# Altium schematic sheet RF.SchDoc(RF)
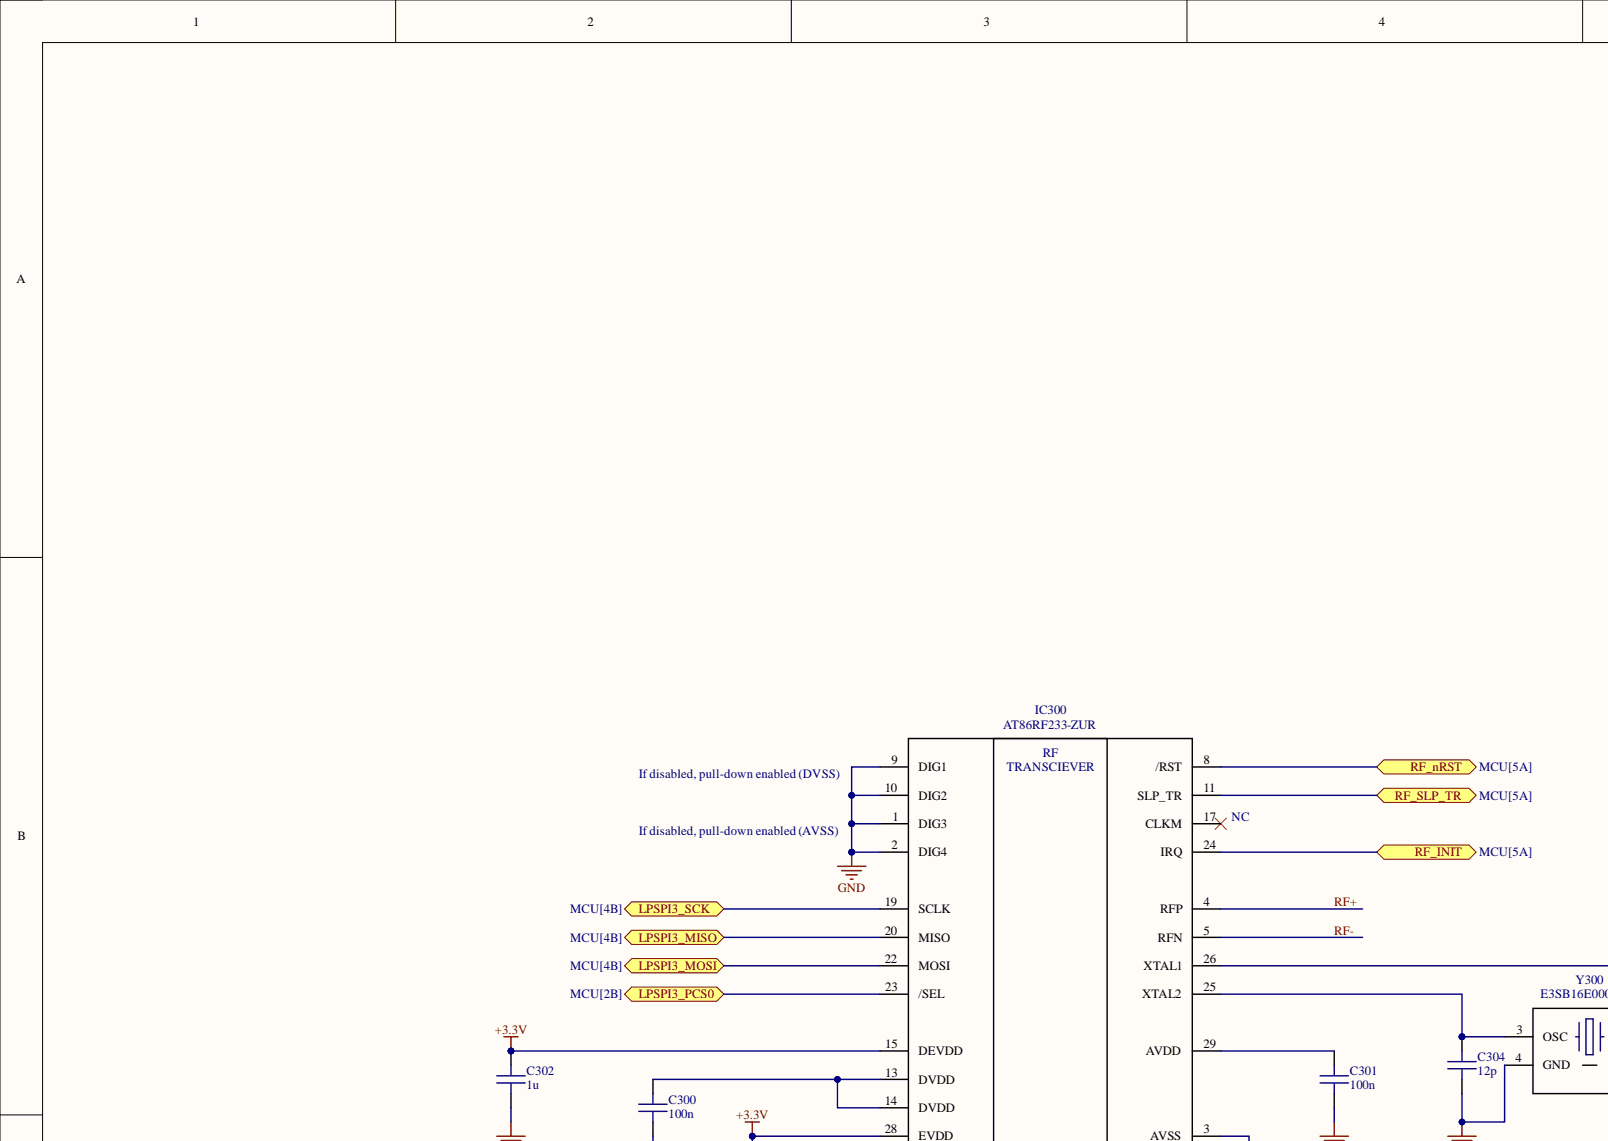
[diagram p0: RF.SchDoc, top left]
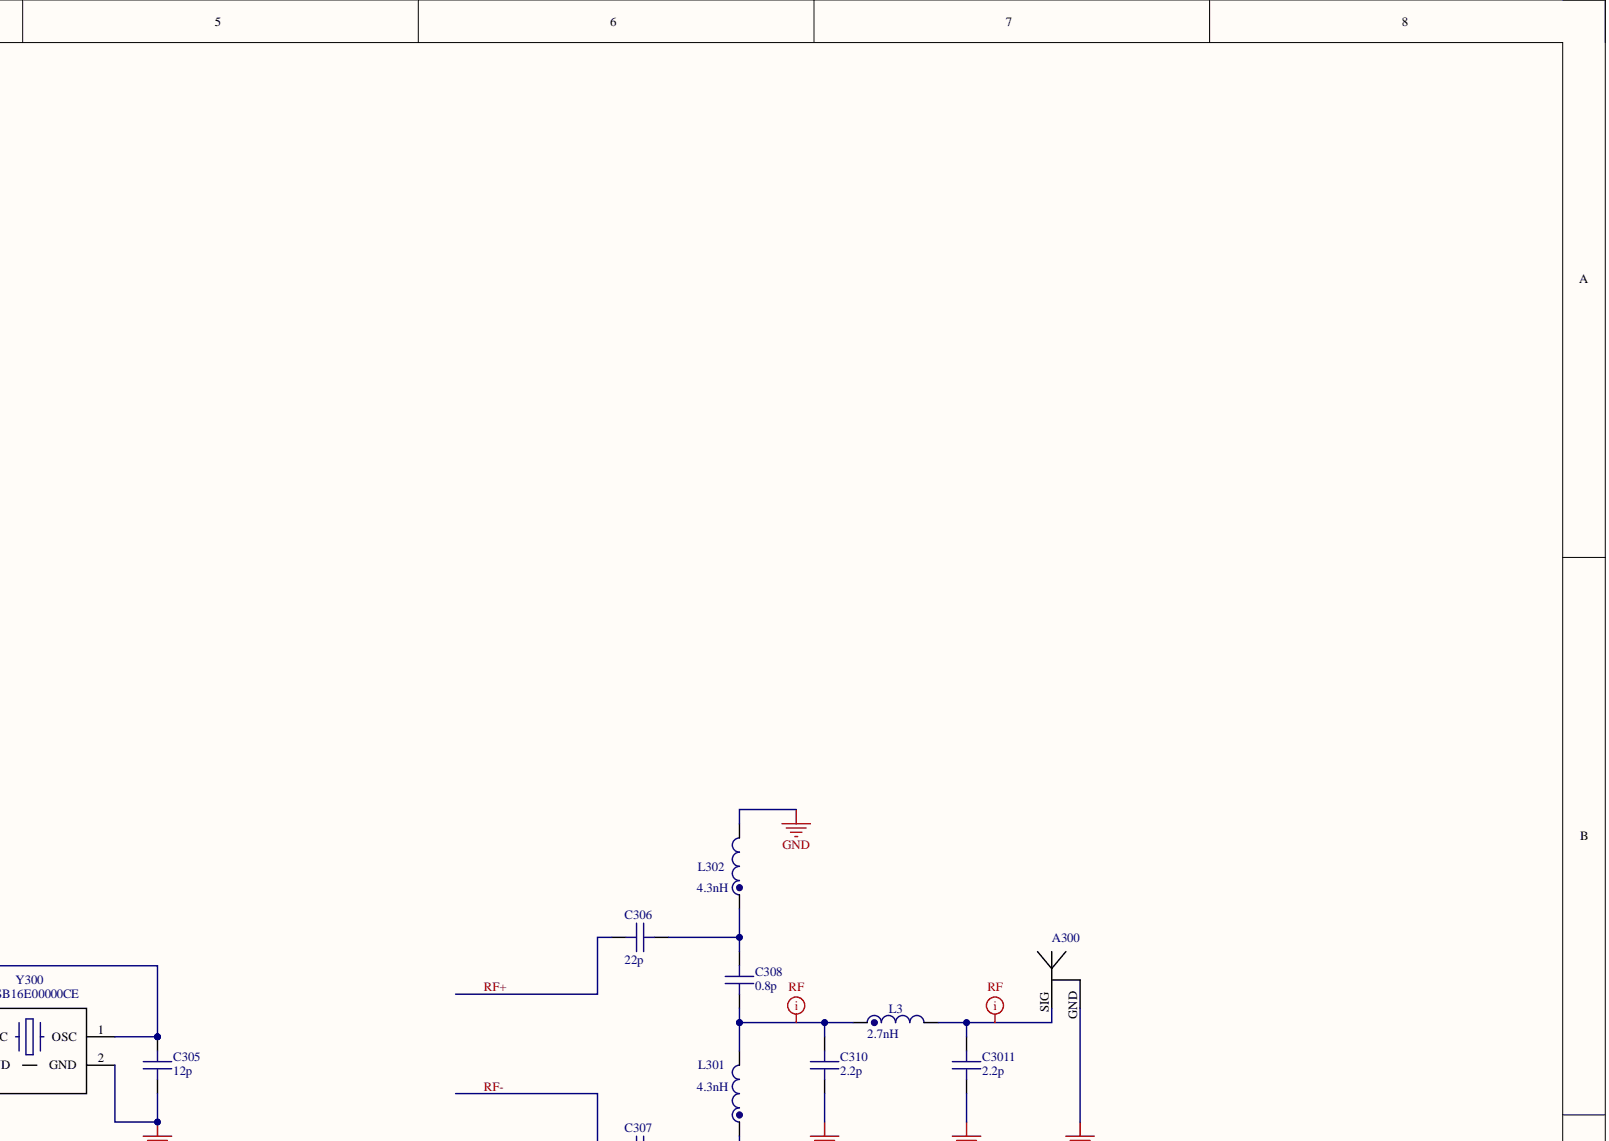
[diagram p1: RF.SchDoc, top right]
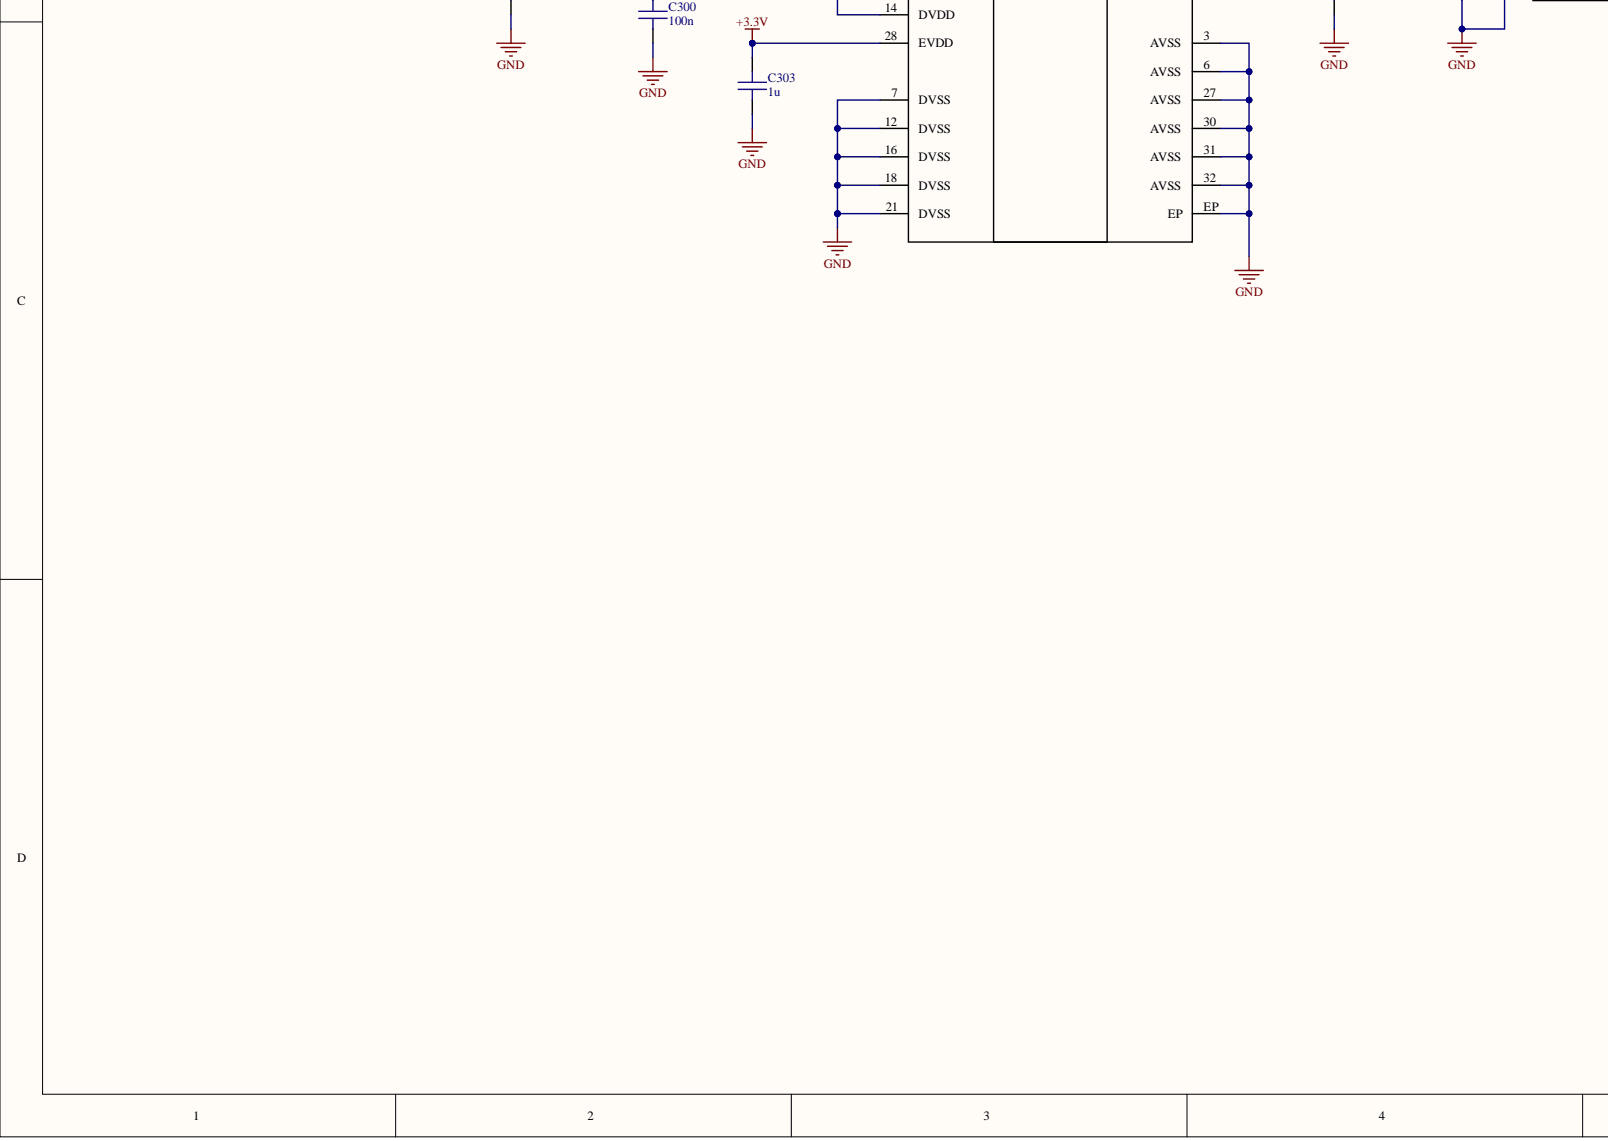
[diagram p2: RF.SchDoc, bottom left]
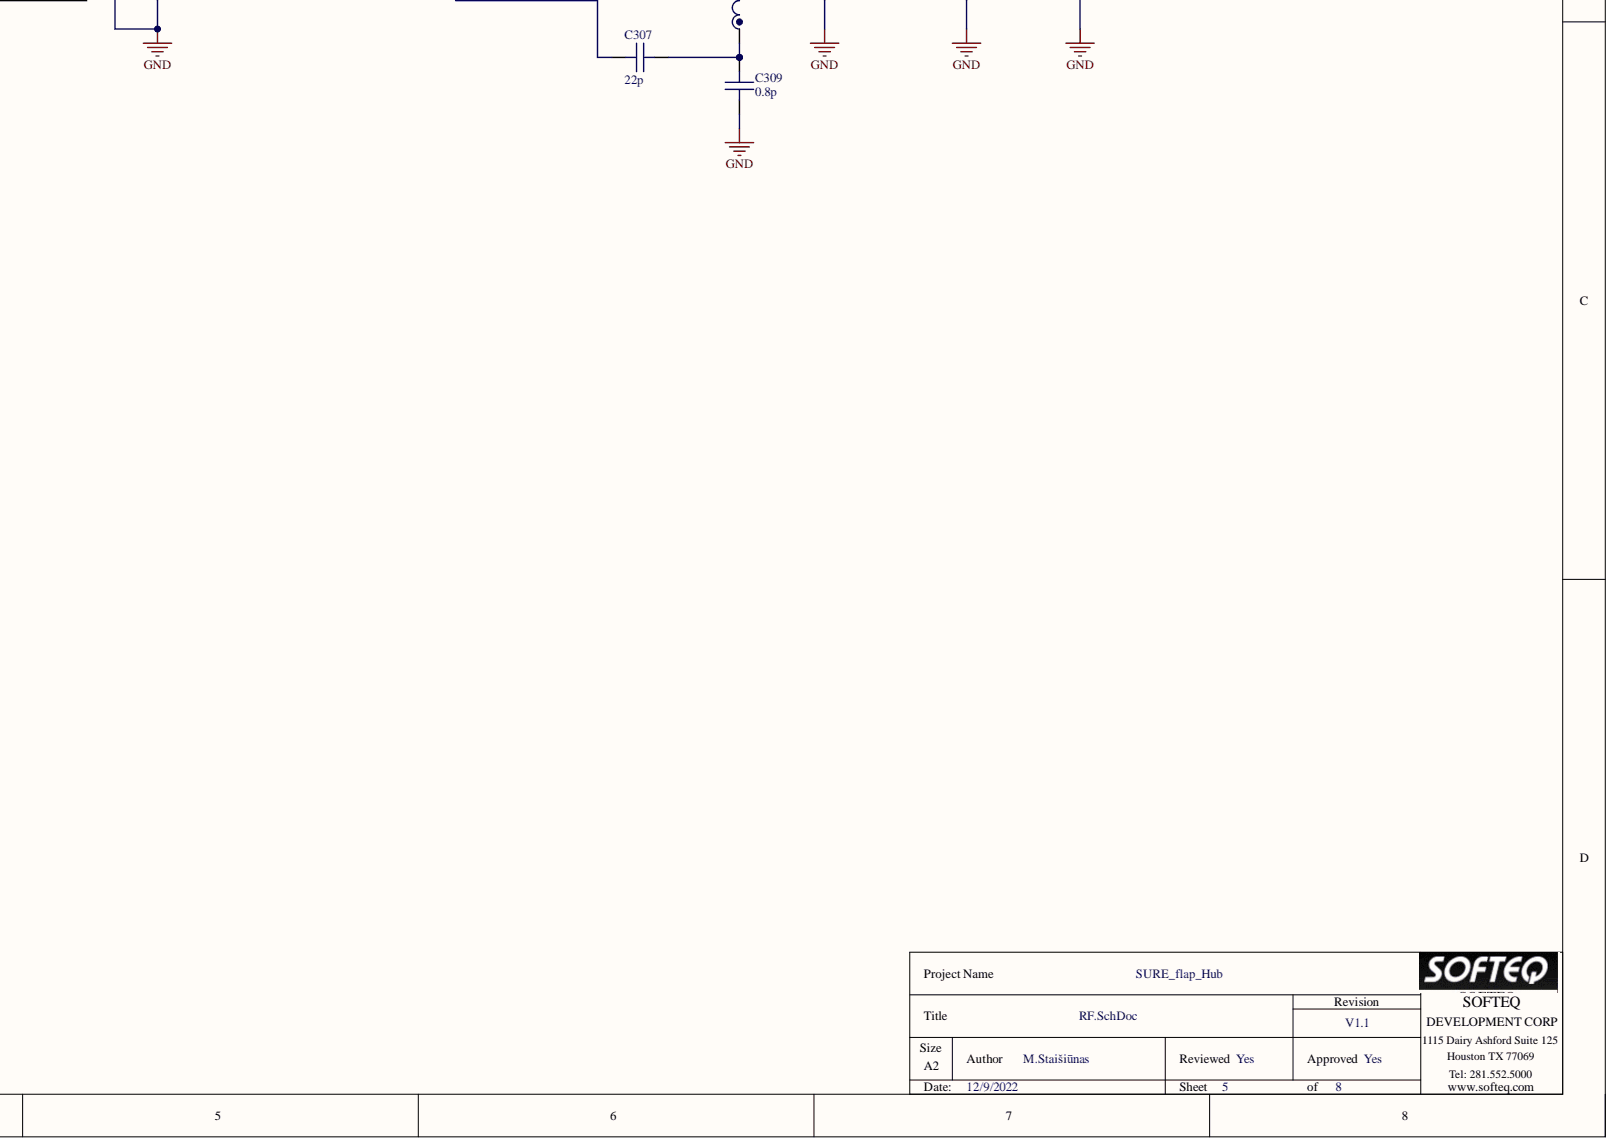
[diagram p3: RF.SchDoc, bottom right]

## Parts
A300  PCB_ANT_2.4_GHZ_18.8X5  [PCB_ANT_2.4_GHZ_18.8X5]  (value not drawn)
C300  value 100n  [C_0402]  (hidden comment: CL05B104KO5NNNC)
C301  value 100n  [C_0402]  (hidden comment: CL05B104KO5NNNC)
C302  value 1u  [C_0402]  (hidden comment: CC0402KRX5R7BB105)
C303  value 1u  [C_0402]  (hidden comment: CC0402KRX5R7BB105)
C304  value 12p  [C_0402]  (hidden comment: CC0402JRNPO9BN120)
C305  value 12p  [C_0402]  (hidden comment: CC0402JRNPO9BN120)
C306  value 22p  [C_0402]  (hidden comment: CC0402JRNPO9BN220)
C307  value 22p  [C_0402]  (hidden comment: CC0402JRNPO9BN220)
C308  value 0.8p  [C_0402]  (hidden comment: GCQ1555C1HR82CB01D)
C309  value 0.8p  [C_0402]  (hidden comment: GCQ1555C1HR82CB01D)
C310  value 2.2p  [C_0402]  (hidden comment: CC0402CRNPO9BN2R2)
C3011  value 2.2p  [C_0402]  (hidden comment: CC0402CRNPO9BN2R2)
IC300  AT86RF233-ZUR  [QFN-32[8x8]_0.30_0.50_5x5x1_EP[3.40x3.40]]
L3  value 2.7nH  [MURATA_0402]  (hidden comment: LQW15AN2N7B00D)
L301  value 4.3nH  [MURATA_0402]  (hidden comment: LQW15AN4N3B00D)
L302  value 4.3nH  [MURATA_0402]  (hidden comment: LQW15AN4N3B00D)
Y300  E3SB16E00000CE  [HOSONIC_E3SB16E00000CE]

## Ports
LPSPI3_MISO, LPSPI3_MOSI, LPSPI3_PCS0, LPSPI3_SCK, RF_INIT, RF_nRST, RF_SLP_TR

## Nets
+3.3V: C302.2 C303.2 IC300.15(DEVDD) IC300.28(EVDD)
GND: A300.GND C300.1 C301.1 C302.1 C303.1 C304.1 C305.1 C309.1 C310.1 C3011.1 IC300.1(DIG3) IC300.2(DIG4) IC300.3(AVSS) IC300.6(AVSS) IC300.7(DVSS) IC300.9(DIG1) IC300.10(DIG2) IC300.12(DVSS) IC300.16(DVSS) IC300.18(DVSS) IC300.21(DVSS) IC300.27(AVSS) IC300.30(AVSS) IC300.31(AVSS) IC300.32(AVSS) IC300.EP L302.2 Y300.2(GND) Y300.4(GND)
LPSPI3_MISO: IC300.20(MISO) ; port LPSPI3_MISO
LPSPI3_MOSI: IC300.22(MOSI) ; port LPSPI3_MOSI
LPSPI3_PCS0: IC300.23(/SEL) ; port LPSPI3_PCS0
LPSPI3_SCK: IC300.19(SCLK) ; port LPSPI3_SCK
RF+: C306.1 IC300.4(RFP)
RF-: C307.1 IC300.5(RFN)
RF_INIT: IC300.24(IRQ) ; port RF_INIT
RF_nRST: IC300.8(/RST) ; port RF_nRST
RF_SLP_TR: IC300.11(SLP_TR) ; port RF_SLP_TR
NetA300_SIG: A300.SIG C3011.2 L3.2
NetC300_2: C300.2 IC300.13(DVDD) IC300.14(DVDD)
NetC301_2: C301.2 IC300.29(AVDD)
NetC304_2: C304.2 IC300.25(XTAL2) Y300.3(OSC)
NetC305_2: C305.2 IC300.26(XTAL1) Y300.1(OSC)
NetC306_2: C306.2 C308.2 L302.1
NetC307_2: C307.2 C309.2 L301.1
NetC308_1: C308.1 C310.2 L3.1 L301.2

Not connected: IC300 17(CLKM)

## Library data of the parts
A300 = PCB_ANT_2.4_GHZ_18.8X5: Antenna, F Antenna, PCB, 2.4GHz, 18.8×5mm; Database Table Name: Other; Component Kind: Net Tie; Library Name: Library.dblib; PartNumber: PCB_ANT_2.4_GHZ_18.8X5; Author: DZ
C300 C301 = CL05B104KO5NNNC: Capacitor, 100nF, 16V, X7R, 10%, -55/+125, 0402; Manufacturer: Samsung; Database Table Name: Capacitors; Component Kind: Standard; ComponentHeight: 0.55mm; Library Name: Library.dblib; Mounted: Yes; PartNumber: CL05B104KO5NNNC; SMD: Yes; Socket: No; Author: KAI
C302 C303 = CC0402KRX5R7BB105: Capacitor, 1uF, 16V, X5R, 10%, -55/+85, 0402; Manufacturer: Yageo; Database Table Name: Capacitors; Component Kind: Standard; ComponentHeight: 0.5mm; Library Name: Library.dblib; Mounted: Yes; PartNumber: CC0402KRX5R7BB105; SMD: Yes; Author: KAI
C304 C305 = CC0402JRNPO9BN120: Capacitor, 12pF, 50V, NP0, 5%, -55/+125, 0402; Manufacturer: Yageo; Database Table Name: Capacitors; Component Kind: Standard; ComponentHeight: 0.5mm; Library Name: Library.dblib; Mounted: Yes; PartNumber: CC0402JRNPO9BN120; SMD: Yes; Author: KAI
C306 C307 = CC0402JRNPO9BN220: Capacitor, 22pF, 50V, NP0, 5%, -55/+125, 0402; Manufacturer: Yageo; Database Table Name: Capacitors; Component Kind: Standard; ComponentHeight: 0.5mm; Library Name: Library.dblib; Mounted: Yes; PartNumber: CC0402JRNPO9BN220; SMD: Yes; Author: KAI
C308 C309 = GCQ1555C1HR82CB01D: Capacitor, 0.8pF, ±0.25pF, 50V, C0G, NP0, -55/+125, 0402; Manufacturer: Murata; Database Table Name: Capacitors; Component Kind: Standard; ComponentHeight: 0.55mm; Library Name: Library.dblib; Mounted: Yes; PartNumber: GCQ1555C1HR82CB01D; SMD: Yes; Author: DZ
C310 C3011 = CC0402CRNPO9BN2R2: Capacitor, 2.2pF, +/-0.25p 50V, C0G, -55/+125, 0402; Manufacturer: Yageo; Database Table Name: Capacitors; Component Kind: Standard; ComponentHeight: 0.55mm; Library Name: Library.dblib; Mounted: Yes; PartNumber: CC0402CRNPO9BN2R2; SMD: Yes; Author: DZ
IC300 = AT86RF233-ZUR: IC, RF Transceiver, 2.4Ghz, ZigBee, 1.8-3.6V, -40/+85, VQFN-32; Manufacturer: Microchip; Database Table Name: IC Digital; Component Kind: Standard; ComponentHeight: 1mm; Library Name: Library.dblib; Mounted: Yes; PartNumber: AT86RF233-ZUR; SMD: Yes; Author: DZ
L3 = LQW15AN2N7B00D: Inductor, 2.7nH, ±0.1nH, 850mA, 50mOhm, -55/+125, 0402; Manufacturer: Murata; Database Table Name: Inductor_Transformer; Resistance: 0.05; Component Kind: Standard; ComponentHeight: 0.6mm; Library Name: Library.dblib; Mounted: Yes; PartNumber: LQW15AN2N7B00D; Power: 850mA; SMD: Yes; Tolerance: ±0.1nH; Author: DZ
L301 L302 = LQW15AN4N3B00D: Inductor, 4.3nH, ±0.1nH, 750mA, 70mOhm, -55/+125, 0402; Manufacturer: Murata; Database Table Name: Inductor_Transformer; Resistance: 0.07; Component Kind: Standard; ComponentHeight: 0.6mm; Library Name: Library.dblib; Mounted: Yes; PartNumber: LQW15AN4N3B00D; Power: 750mA; SMD: Yes; Tolerance: ±0.1nH; Author: DZ
Y300 = E3SB16E00000CE: Crystal, 16MHz, ±10ppm, 9pF, 3.2x2.5x0.75mm, -30/+85, SMD; Manufacturer: Hosonic; Database Table Name: Resonators_Generator; Component Kind: Standard; ComponentHeight: 0.75mm; Library Name: Library.dblib; Mounted: Yes; PartNumber: E3SB16E00000CE; SMD: Yes; Value: 16MHz; Author: DZ
